# Altium schematic sheet 1_CPUs_rC0.SchDoc
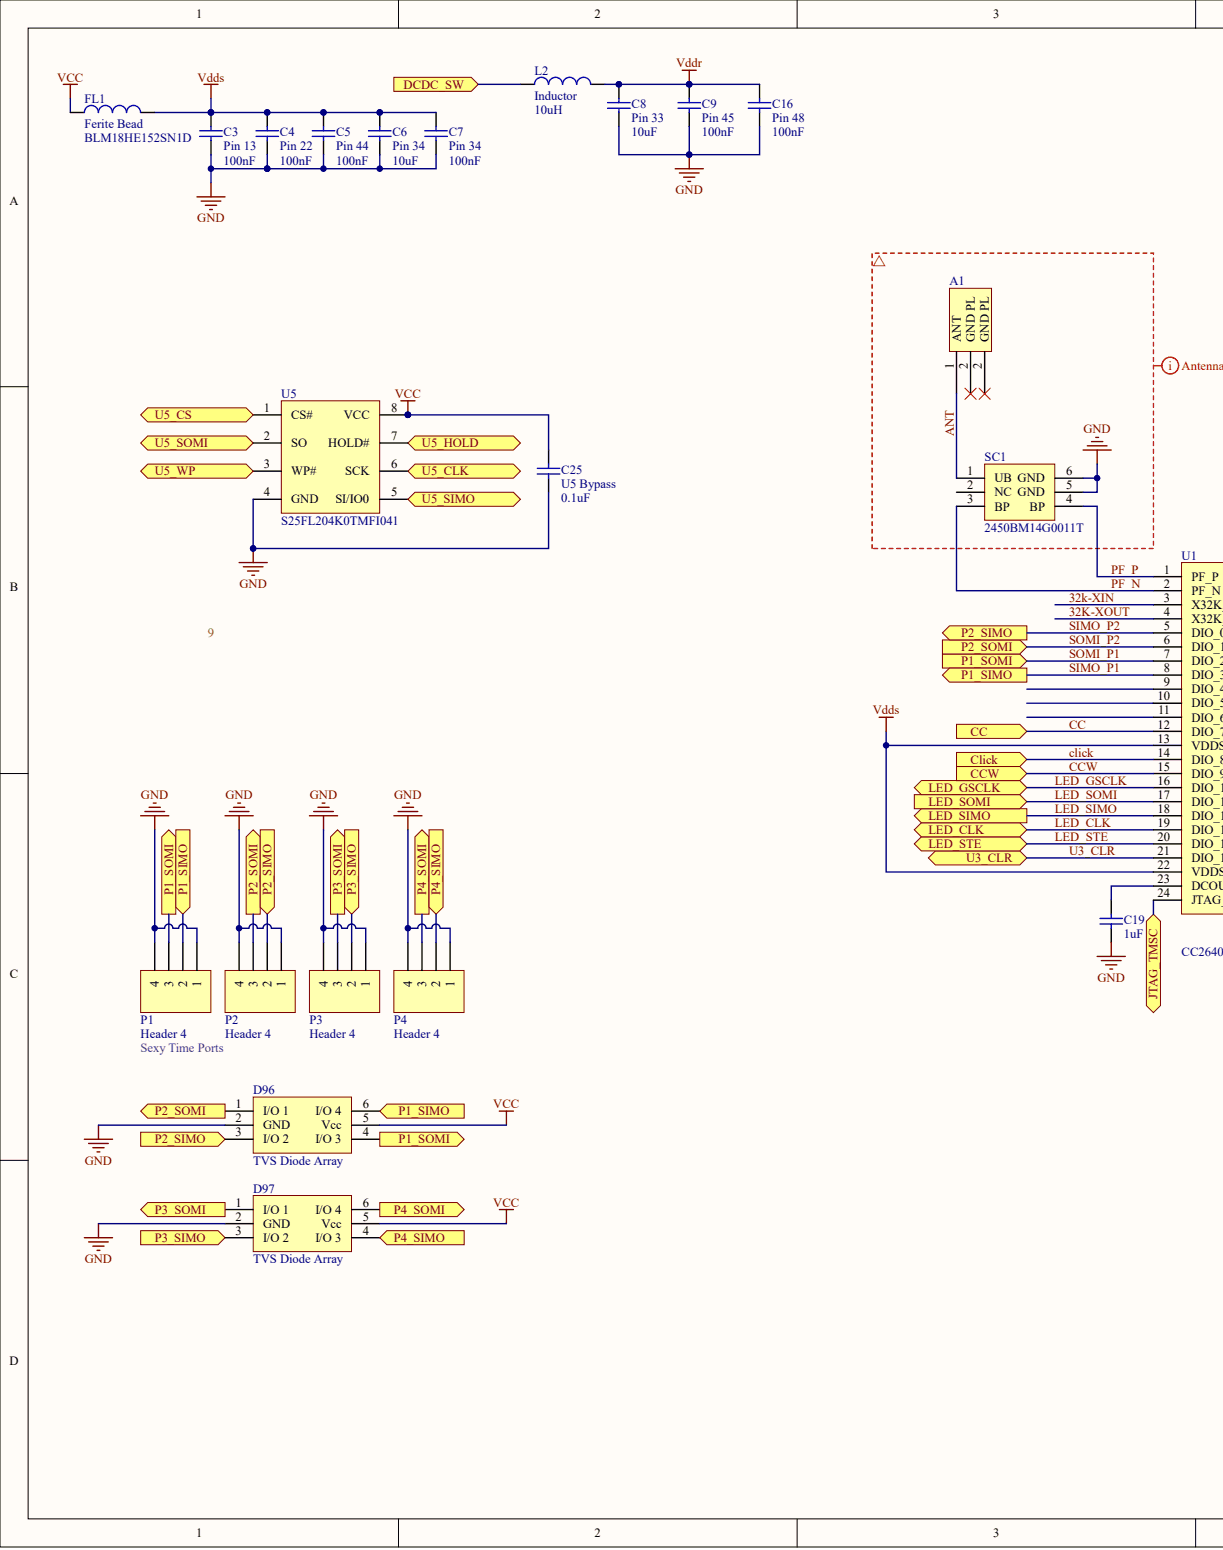
[diagram p0: 1_CPUs_rC0.SchDoc, left]
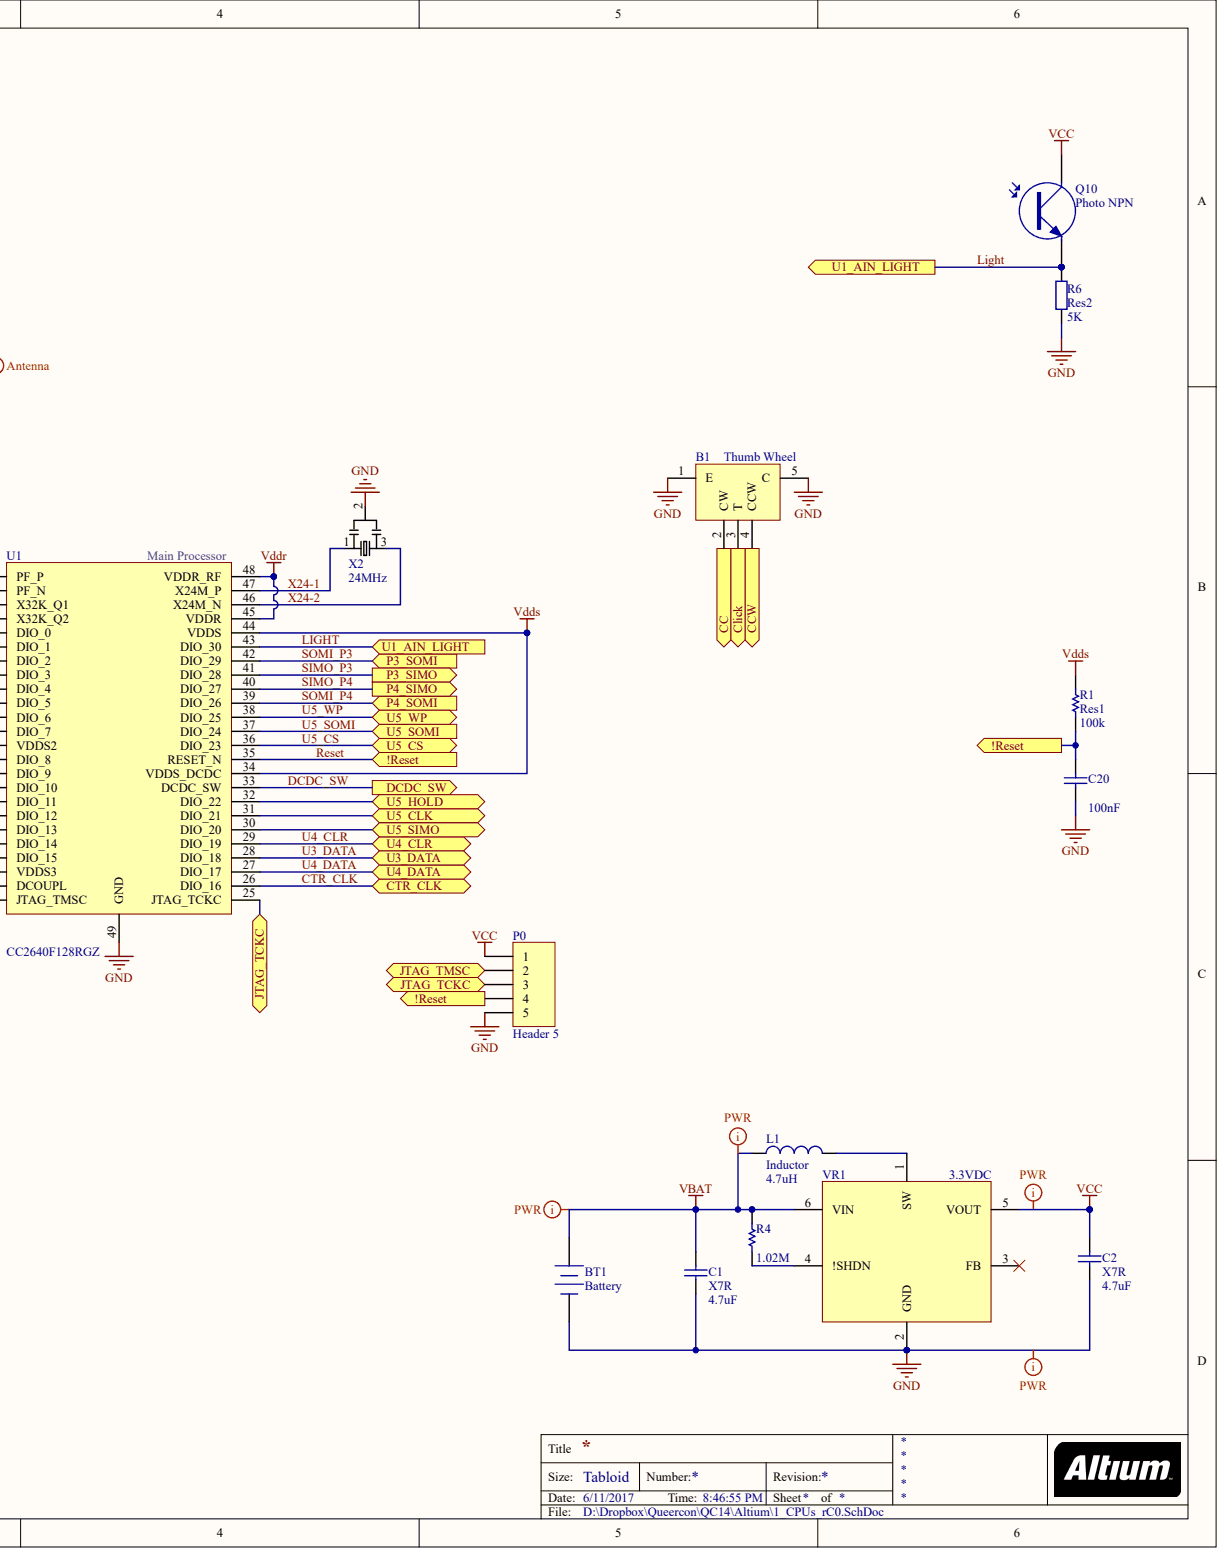
[diagram p1: 1_CPUs_rC0.SchDoc, right]

## Parts
A1  ANT
B1  Thumb Wheel
BT1  Battery
C1  X7R  value 4.7uF
C2  X7R  value 4.7uF
C3  Pin 13  value 100nF
C4  Pin 22  value 100nF
C5  Pin 44  value 100nF
C6  Pin 34  value 10uF
C7  Pin 34  value 100nF
C8  Pin 33  value 10uF
C9  Pin 45  value 100nF
C16  Pin 48  value 100nF
C19  value 1uF  (hidden comment: Decoupling)
C20  value 100nF
C25  U5 Bypass  value 0.1uF
D96  TVS Diode Array
D97  TVS Diode Array
FL1  Ferite Bead  value BLM18HE152SN1D
L1  Inductor  value 4.7uH
L2  Inductor  value 10uH
P0  Header 5
P1  Header 4
P2  Header 4
P3  Header 4
P4  Header 4
Q10  Photo NPN
R1  Res1  value 100k
R4  value 1.02M  (hidden comment: Res1)
R6  Res2  value 5K
SC1  2450BM14G0011T
U1  CC2640F128RGZ
U5  S25FL204K0TMFI041
VR1  3.3VDC
X2  24MHz

## Ports
!RESET, CC, CCW, CLICK, CTR_CLK, DCDC_SW, JTAG_TCKC, JTAG_TMSC, LED_CLK, LED_GSCLK, LED_SIMO, LED_SOMI, LED_STE, P1_SIMO, P1_SOMI, P2_SIMO, P2_SOMI, P3_SIMO, P3_SOMI, P4_SIMO, P4_SOMI, U1_AIN_LIGHT, U3_CLR, U3_DATA, U4_CLR, U4_DATA, U5_CLK, U5_CS, U5_HOLD, U5_SIMO, U5_SOMI, U5_WP

## Nets
GND: B1.1(E) B1.5(C) BT1.2 C1.1 C2.1 C3.1 C4.1 C5.1 C6.1 C7.1 C8.1 C9.1 C16.1 C19.1 C20.1 C25.1 D96.2(GND) D97.2(GND) P0.5 P1.1 P1.4 P2.1 P2.4 P3.1 P3.4 P4.1 P4.4 R6.1 SC1.5(GND) SC1.6(GND) U1.49(GND) U5.4(GND) VR1.2(GND) X2.2
VBAT: BT1.1 C1.2 L1.1 R4.2 VR1.6(VIN)
VCC: C2.2 C25.2 D96.5(Vcc) D97.5(Vcc) FL1.1 P0.1 Q10.1 U5.8(VCC) VR1.5(VOUT)
VDDR: C8.2 C9.2 C16.2 L2.2 U1.45(VDDR) U1.48(VDDR_RF)
VDDS: C3.2 C4.2 C5.2 C6.2 C7.2 FL1.2 R1.1 U1.13(VDDS2) U1.22(VDDS3) U1.34(VDDS_DCDC) U1.44(VDDS)
32K-XIN: U1.3(X32K_Q1)
32K-XOUT: U1.4(X32K_Q2)
ANT: A1.1 SC1.1(UB)
CC: B1.2(CW) U1.12(DIO_7) ; port CC
CCW: B1.4(CCW) U1.15(DIO_9) ; port CCW
CLICK: B1.3(T) U1.14(DIO_8) ; port CLICK
CTR_CLK: U1.26(DIO_16) ; port CTR_CLK
DCDC_SW: L2.1 U1.33(DCDC_SW) ; port DCDC_SW
JTAG_TCKC: P0.3 U1.25(JTAG_TCKC) ; port JTAG_TCKC
JTAG_TMSC: P0.2 U1.24(JTAG_TMSC) ; port JTAG_TMSC
LED_CLK: U1.19(DIO_13) ; port LED_CLK
LED_GSCLK: U1.16(DIO_10) ; port LED_GSCLK
LED_SIMO: U1.18(DIO_12) ; port LED_SIMO
LED_SOMI: U1.17(DIO_11) ; port LED_SOMI
LED_STE: U1.20(DIO_14) ; port LED_STE
LIGHT: Q10.3 R6.2 U1.43(DIO_30) ; port U1_AIN_LIGHT
PF_N: SC1.3(BP) U1.2(PF_N)
PF_P: SC1.4(BP) U1.1(PF_P)
RESET: C20.2 P0.4 R1.2 U1.35(RESET_N) ; port !RESET
SIMO_P1: D96.6(I/O_4) P1.2 U1.8(DIO_3) ; port P1_SIMO
SIMO_P2: D96.3(I/O_2) P2.2 U1.5(DIO_0) ; port P2_SIMO
SIMO_P3: D97.3(I/O_2) P3.2 U1.41(DIO_28) ; port P3_SIMO
SIMO_P4: D97.4(I/O_3) P4.2 U1.40(DIO_27) ; port P4_SIMO
SOMI_P1: D96.4(I/O_3) P1.3 U1.7(DIO_2) ; port P1_SOMI
SOMI_P2: D96.1(I/O_1) P2.3 U1.6(DIO_1) ; port P2_SOMI
SOMI_P3: D97.1(I/O_1) P3.3 U1.42(DIO_29) ; port P3_SOMI
SOMI_P4: D97.6(I/O_4) P4.3 U1.39(DIO_26) ; port P4_SOMI
U3_CLR: U1.21(DIO_15) ; port U3_CLR
U3_DATA: U1.28(DIO_18) ; port U3_DATA
U4_CLR: U1.29(DIO_19) ; port U4_CLR
U4_DATA: U1.27(DIO_17) ; port U4_DATA
U5_CLK: U1.31(DIO_21) U5.6(SCK) ; port U5_CLK
U5_CS: U1.36(DIO_23) U5.1(CS#) ; port U5_CS
U5_HOLD: U1.32(DIO_22) U5.7(HOLD#) ; port U5_HOLD
U5_SIMO: U1.30(DIO_20) U5.5(SI/IO0) ; port U5_SIMO
U5_SOMI: U1.37(DIO_24) U5.2(SO) ; port U5_SOMI
U5_WP: U1.38(DIO_25) U5.3(WP#) ; port U5_WP
X24-1: U1.47(X24M_P) X2.1
X24-2: U1.46(X24M_N) X2.3
NetC19_2: C19.2 U1.23(DCOUPL)
NetL1_2: L1.2 VR1.1(SW)
NetR4_1: R4.1 VR1.4(!SHDN)
N00013:

Not connected: A1 2; SC1 2(NC); U1 9(DIO_4) 10(DIO_5) 11(DIO_6); VR1 3(FB)

## Library data of the parts
FL1 = (no symbol name): Code_IEC: 1005; Value: BLM18HE152SN1D; Published: 8-Jun-2000; LatestRevisionDate: 29-May-2009; LatestRevisionNote: IPC-7351 Footprint Added.; PackageReference: 0402-A; PackageDescription: Chip Inductor; Code_JEITA: 0402; Publisher: Altium Limited
L1 = (no symbol name): Code_IEC: 1005; Value: 4.7uH; Published: 8-Jun-2000; LatestRevisionDate: 29-May-2009; LatestRevisionNote: IPC-7351 Footprint Added.; PackageReference: 0402-A; PackageDescription: Chip Inductor; Code_JEITA: 0402; Publisher: Altium Limited
L2 = (no symbol name): Code_IEC: 1005; Published: 8-Jun-2000; LatestRevisionDate: 29-May-2009; LatestRevisionNote: IPC-7351 Footprint Added.; PackageReference: 0402-A; PackageDescription: Chip Inductor; Code_JEITA: 0402; Publisher: Altium Limited
X2 = (no symbol name): Manufacturer: ECS; PN: X908-ND; PackageReference: 5x10mm
A1 B1 BT1 C1-C9 C16 C19 C20 C25 D96 D97 P0-P4 Q10 R1 R4 R6 SC1 U1 U5 VR1 = (no symbol name)
  BT1: Published: 24-Mar-1999; LatestRevisionDate: 17-Jul-2002; LatestRevisionNote: Re-released for DXP Platform.; PackageReference: BAT-2; PackageDescription: Battery; 2 Leads
  C1-C9 C16 C19 C20 C25: Published: 8-Jun-2000; LatestRevisionDate: 17-Jul-2002; LatestRevisionNote: Re-released for DXP Platform.; PackageReference: RAD-0.3; PackageDescription: Radial Cap, Thru-Hole; 2 Leads; 0.3 in Pin Spacing
  P0-P4: LatestRevisionDate: 17-Jul-2002; LatestRevisionNote: Re-released for DXP Platform.
  Q10: Published: 24-Mar-1999; LatestRevisionDate: 17-Jul-2002; LatestRevisionNote: Re-released for DXP Platform.; PackageReference: TO-220_A; Code_JEDEC: TO-220-AC
  R1 R4: Published: 8-Jun-2000; LatestRevisionDate: 17-Jul-2002; LatestRevisionNote: Re-released for DXP Platform.; PackageReference: AXIAL-0.3; PackageDescription: Axial Device, Thru-Hole; 2 Leads; 0.3 in Pin Spacing
  R6: Published: 8-Jun-2000; LatestRevisionDate: 17-Jul-2002; LatestRevisionNote: Re-released for DXP Platform.; PackageReference: AXIAL-0.4
  BT1 C1-C9 C16 C19 C20 C25 P0-P4 Q10 R1 R4 R6: Publisher: Altium Limited
